# Altium schematic sheet us_#2shc.SchDoc(us_#2shc)
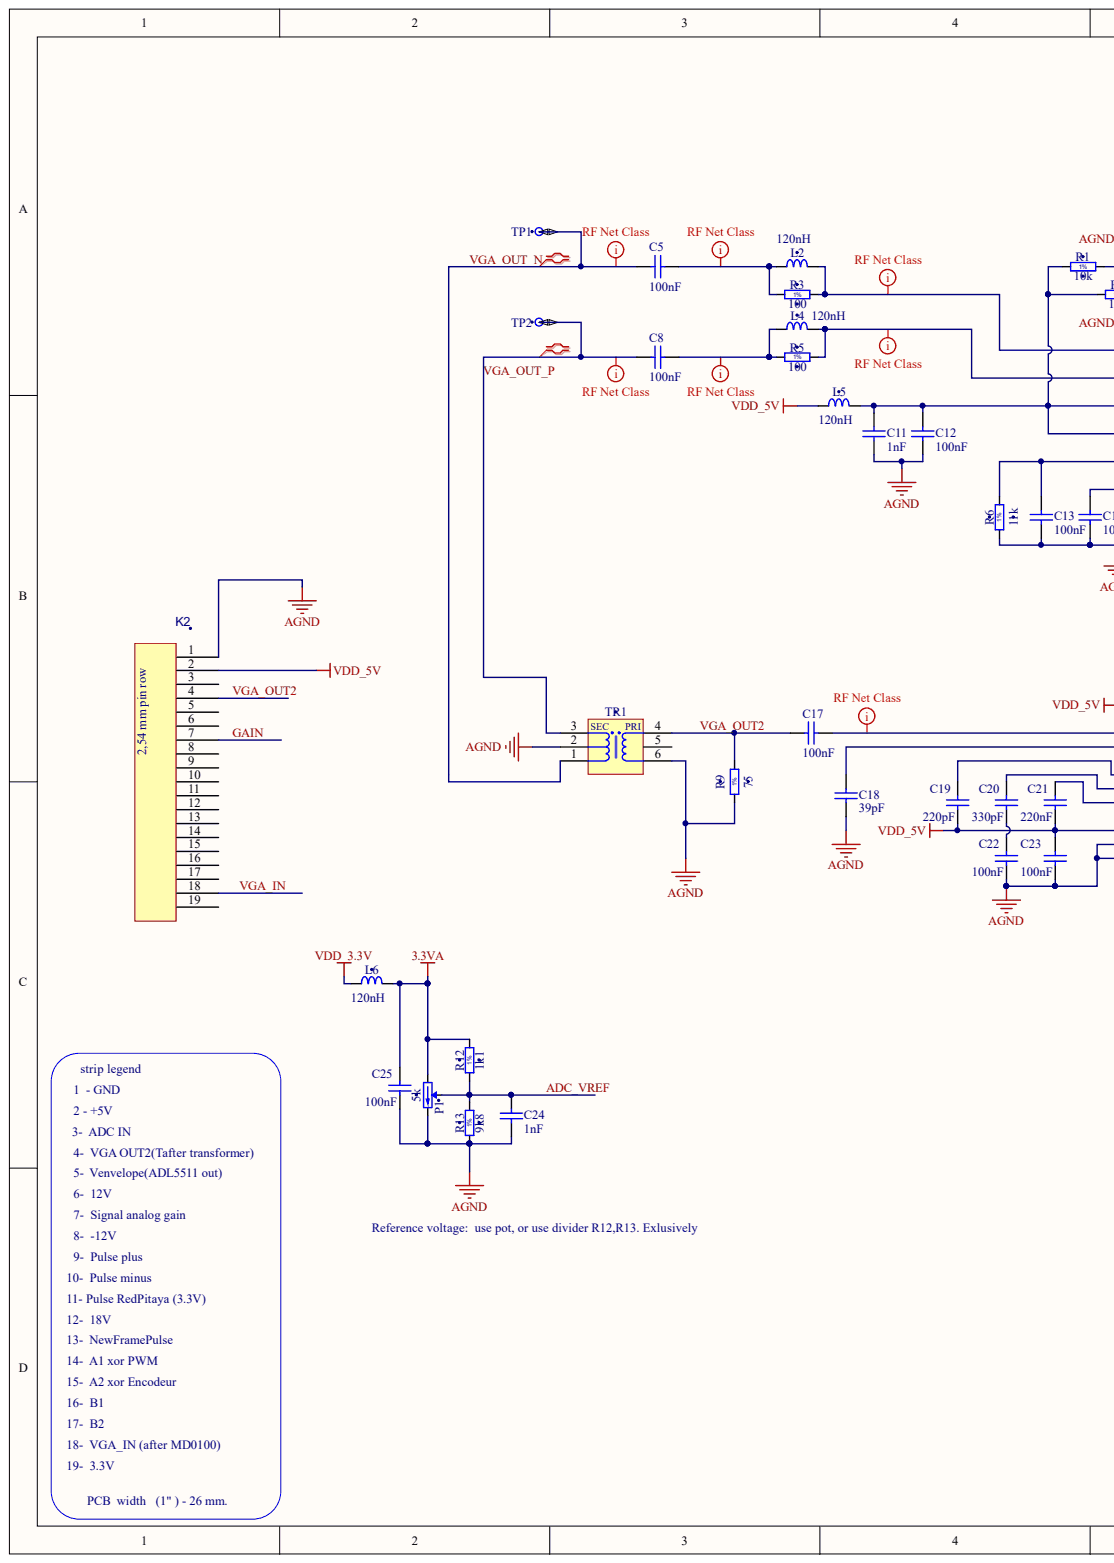
[diagram p0: us_#2shc.SchDoc, left]
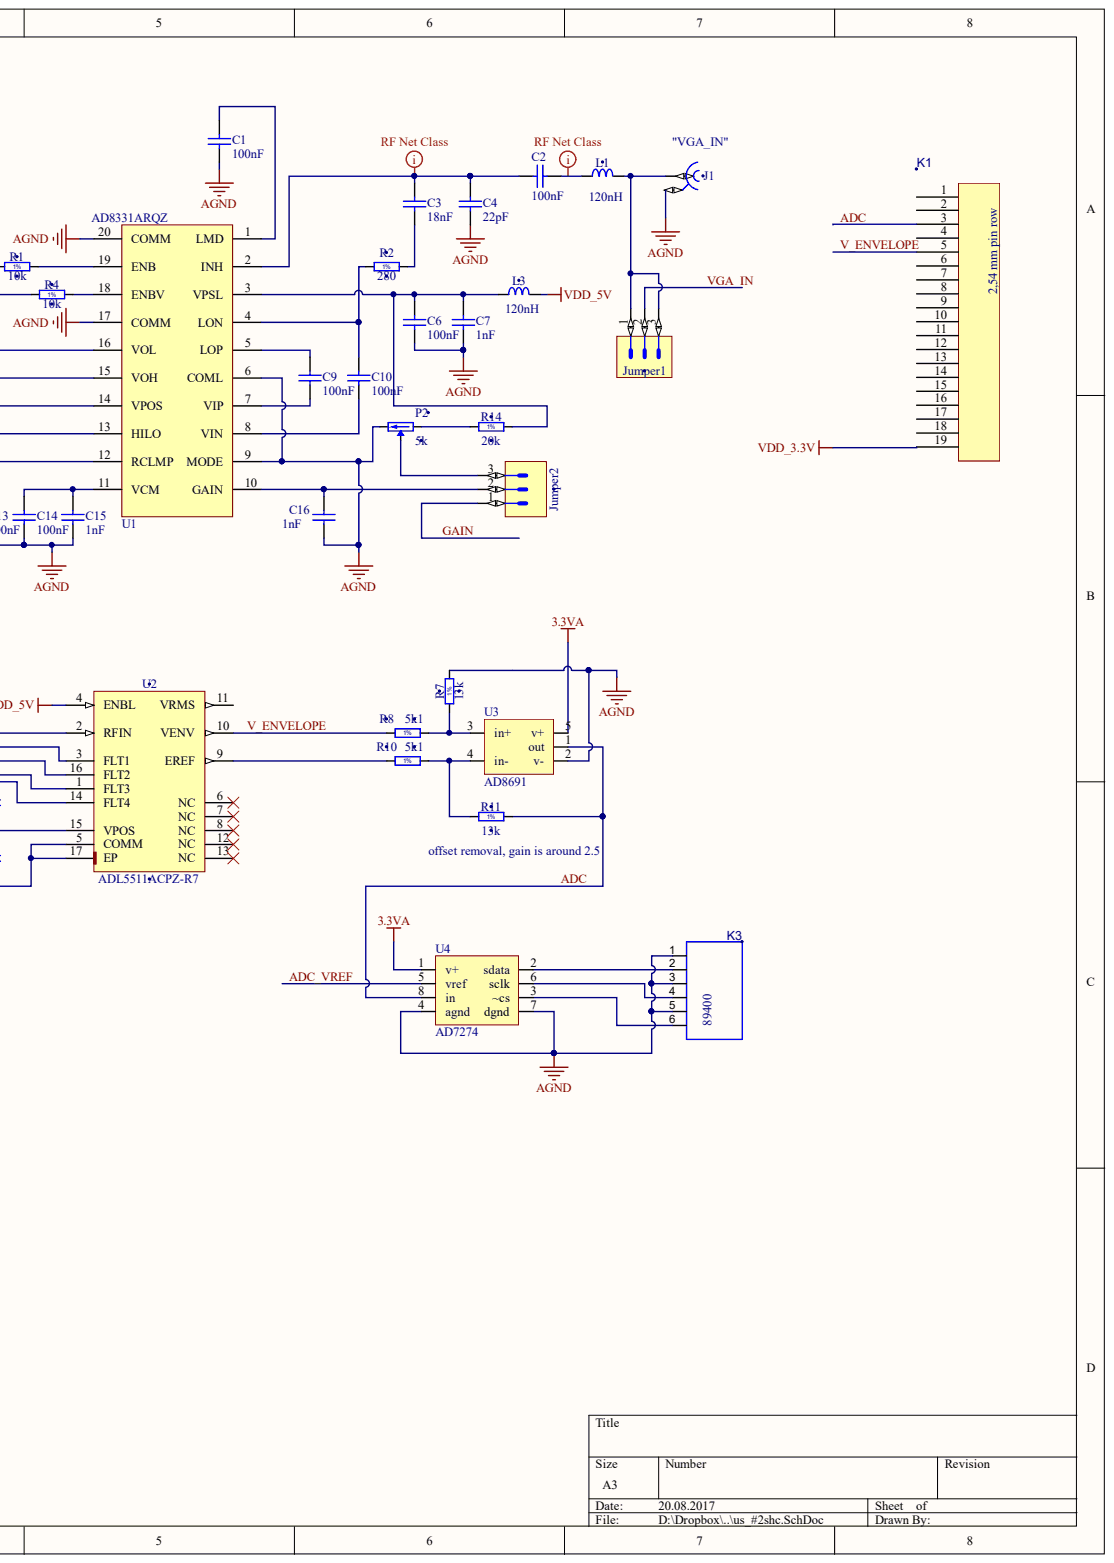
[diagram p1: us_#2shc.SchDoc, right]

## Parts
C1  value 100nF  [SM0603 _3D_cap]  (hidden comment: cap0603)
C2  value 100nF  [SM0603 _3D_cap]  (hidden comment: cap0603)
C3  value 18nF  [SM0603 _3D_cap]  (hidden comment: cap0603)
C4  value 22pF  [SM0603 _3D_cap]  (hidden comment: cap0603)
C5  value 100nF  [SM0603 _3D_cap]  (hidden comment: cap0603)
C6  value 100nF  [SM0603 _3D_cap]  (hidden comment: cap0603)
C7  value 1nF  [SM0603 _3D_cap]  (hidden comment: cap0603)
C8  value 100nF  [SM0603 _3D_cap]  (hidden comment: cap0603)
C9  value 100nF  [SM0603 _3D_cap]  (hidden comment: cap0603)
C10  value 100nF  [SM0603 _3D_cap]  (hidden comment: cap0603)
C11  value 1nF  [SM0603 _3D_cap]  (hidden comment: cap0603)
C12  value 100nF  [SM0603 _3D_cap]  (hidden comment: cap0603)
C13  value 100nF  [SM0603 _3D_cap]  (hidden comment: cap0603)
C14  value 100nF  [SM0603 _3D_cap]  (hidden comment: cap0603)
C15  value 1nF  [SM0603 _3D_cap]  (hidden comment: cap0603)
C16  value 1nF  [SM0603 _3D_cap]  (hidden comment: cap0603)
C17  value 100nF  [SM0603 _3D_cap]  (hidden comment: cap0603)
C18  value 39pF  [SM0603 _3D_cap]  (hidden comment: cap0603)
C19  value 220pF  [SM0603 _3D_cap]  (hidden comment: cap0603)
C20  value 330pF  [SM0603 _3D_cap]  (hidden comment: cap0603)
C21  value 220nF  [SM0603 _3D_cap]  (hidden comment: cap0603)
C22  value 100nF  [SM0603 _3D_cap]  (hidden comment: cap0603)
C23  value 100nF  [SM0603 _3D_cap]  (hidden comment: cap0603)
C24  value 1nF  [SM0603 _3D_cap]  (hidden comment: cap0603)
C25  value 100nF  [SM0603 _3D_cap]  (hidden comment: cap0603)
J1  SMA  [AMPHENOL_132357-11]  (value not drawn)
Jumper1  Family  [SULLINS_GRPB031VWVN]  (value not drawn)
Jumper2  Family  [SULLINS_GRPB031VWVN]  (value not drawn)
K1  PLS_2.54mm-19  [PLS_2.54mm-19]  (value not drawn)
K2  PLS_2.54mm-19  [PLS_2.54mm-19]  (value not drawn)
K3  Molex_89400_061  [Molex_89400-061]  (value not drawn)
L1  75R@100MHz  [INDC2012X105N]  (value not drawn)
L2  75R@100MHz  [INDC2012X105N]  (value not drawn)
L3  75R@100MHz  [INDC2012X105N]  (value not drawn)
L4  75R@100MHz  [INDC2012X105N]  (value not drawn)
L5  75R@100MHz  [INDC2012X105N]  (value not drawn)
L6  75R@100MHz  [INDC2012X105N]  (value not drawn)
P1  5k  [TRIM_BOURNS_3223W]
P2  5k  [TRIM_BOURNS_3223W]
R1  10k  [RESC3216X65N]
R2  280  [RESC3216X65N]
R3  100  [RESC3216X65N]
R4  10k  [RESC3216X65N]
R5  100  [RESC3216X65N]
R6  11k  [RESC3216X65N]
R7  13k  [RESC3216X65N]
R8  5k1  [RESC3216X65N]
R9  75  [RESC3216X65N]
R10  5k1  [RESC3216X65N]
R11  13k  [RESC3216X65N]
R12  1k1  [RESC3216X65N]
R13  9k8  [RESC3216X65N]
R14  20k  [RESC3216X65N]
TP1  Test Point  [NICOMATIC_C12000B]  (value not drawn)
TP2  Test Point  [NICOMATIC_C12000B]  (value not drawn)
TR1  1:1  [XFMR_MINI-CIRCUITS_KK81]  (value not drawn)
U1  AD8331ARQZ  [SOP64P600X175-20N]
U2  ADL5511ACPZ-R7  [QFN50P300X300X80-17N-S175]
U3  AD8691  [TSOT-5(RT-5)]
U4  AD7274  [RM-8]

## Nets
3.3VA: C25.2 L6.2 P1.1 R12.2 U3.5(v+) U4.1(v+)
AGND: C1.1 C4.1 C6.1 C7.1 C11.1 C12.1 C13.1 C14.1 C15.1 C16.1 C18.1 C22.1 C23.1 C24.1 C25.1 J1.2 K2.1 K3.1 K3.3 K3.5 P1.3 P2.3 R6.1 R7.2 R9.1 R13.1 TR1.2 TR1.6 U1.6(COML) U1.9(MODE) U1.17(COMM) U1.20(COMM) U2.5(COMM) U2.17(EP) U3.2(v-) U4.4(agnd) U4.7(dgnd)
VDD_3.3V: K1.19 L6.1
VDD_5V: C19.1 C21.1 C23.2 K2.2 L3.2 L5.1 U2.4(ENBL) U2.15(VPOS)
ADC: K1.3 R11.2 U3.1(out) U4.8(in)
ADC_VREF: C24.2 P1.2 R12.1 R13.2 U4.5(vref)
GAIN: Jumper2.1 K2.7
V_ENVELOPE: K1.5(mm) R8.1 U2.10(VENV)
VGA_IN: Jumper1.2 K2.18
VGA_OUT2: C17.1 K2.4 R9.2 TR1.4
VGA_OUT_N: C5.1 TP1.1 TR1.1
VGA_OUT_P: C8.2 TP2.1 TR1.3
NetC1_2: C1.2 U1.1(LMD)
NetC2_1: C2.1 C3.2 C4.2 U1.2(INH)
NetC2_2: C2.2 L1.1
NetC3_1: C3.1 R2.2
NetC5_2: C5.2 L2.1 R3.1
NetC6_2: C6.2 C7.2 L3.1 R14.2 U1.3(VPSL)
NetC8_1: C8.1 L4.1 R5.1
NetC9_1: C9.1 U1.7(VIP)
NetC9_2: C9.2 U1.5(LOP)
NetC10_1: C10.1 U1.8(VIN)
NetC10_2: C10.2 R2.1 U1.4(LON)
NetC11_2: C11.2 C12.2 L5.2 R1.1 R4.1 U1.13(HILO) U1.14(VPOS)
NetC13_2: C13.2 R6.2 U1.12(RCLMP)
NetC14_2: C14.2 C15.2 U1.11(VCM)
NetC16_2: C16.2 Jumper2.2 U1.10(GAIN)
NetC17_2: C17.2 U2.2(RFIN)
NetC18_2: C18.2 U2.3(FLT1)
NetC19_2: C19.2 U2.16(FLT2)
NetC20_1: C20.1 C22.2
NetC20_2: C20.2 U2.1(FLT3)
NetC21_2: C21.2 U2.14(FLT4)
NetJ1_1: J1.1 Jumper1.1 Jumper1.3 L1.2
NetJumper2_3: Jumper2.3 P2.2
NetK3_2: K3.2 U4.2(sdata)
NetK3_4: K3.4 U4.6(sclk)
NetK3_6: K3.6 U4.3(~cs)
NetL2_2: L2.2 R3.2 U1.16(VOL)
NetL4_2: L4.2 R5.2 U1.15(VOH)
NetP2_1: P2.1 R14.1
NetR1_2: R1.2 U1.19(ENB)
NetR4_2: R4.2 U1.18(ENBV)
NetR7_1: R7.1 R8.2 U3.3(in+)
NetR10_1: R10.1 U2.9(EREF)
NetR10_2: R10.2 R11.1 U3.4(in-)

Not connected: K1 1 2 4 6 7 8 9 10 11 12 13 14 15 16 17 18; K2 3 5(mm) 6 8 9 10 11 12 13 14 15 16 17 19; TR1 5; U2 6(NC) 7(NC) 8(NC) 11(VRMS) 12(NC) 13(NC)

## Library data of the parts
C1-C25 = cap0603: Capacitor  0603 inch; Component Kind: Standard; LatestRevisionDate: 17-Jul-2002; LatestRevisionNote: Re-released for DXP Platform.; PackageDescription: Radial Cap, Thru-Hole; 2 Leads; 0.3 in Pin Spacing; PackageReference: RAD-0.3; Published: 8-Jun-2000; Signal Integrity: Cap; Library Name: alt_vovan.IntLib; Publisher: Altium Limited
J1 = Coax_1Female_1Shield: Manufacturer: AMPHENOL; Manufacturer Part Number: 132357-11; Part Description: SMA End Launch Jack for 1.58mm PCB, 50 Ohm, Flat Tab Contact; ComponentHeight: 7.92mm; Component Kind: Standard; Component Type: Standard; CreateDate: 5/14/2012; Family: SMA; HelpURL: \\cern.ch\dfs\Applications\Altium\Datasheets\AMPHENOL_132357-11.pdf; LatestRevisionDate: 5/14/2012; Mounted: Yes; Part Number: AMPHENOL_132357-11; Pin Count: 2; SMD: Yes; Socket: No; Status: None; Table: AMPHENOL; Author: CERN DEM JLC; Library Name: echOpen_ADC_Card.IntLib
Jumper1 Jumper2 = SULLINS_GRPB031VWVN: Connector 3 Male; Part Description: 3 Contact 0.5" Pitch Single-In-Line Vertical Header; Database Table Name: Miscellaneous; ComponentHeight: 4mm; Component Kind: Standard; Component Type: Standard; CreateDate: 12/29/2015; LatestRevisionDate: 12/29/2015; Manufacturer: SULLINS; Manufacturer Part Number: GRPB031VWVN; Mounted: Yes; Part Number: SULLINS_GRPB031VWVN; Pin Count: 3; SMD: No; Socket: No; Status: None; Table: Miscellaneous; Author: S. Jafar; Library Name: Sof-Connectors.DbLib
K3 = Molex_89400_061: 6 pin vertical 2mm; Component Kind: Standard; Library Name: alt_vovan.IntLib
L1-L6 = Ind_Chip: chip inductance; Manufacturer: MURATA; Manufacturer Part Number: BLM21BB750SN1D; Part Description: SMD EMIFIL Suppression Chip Ferrite Bead; Part Number: IND0805_MURATA_BLM21BB750SN1D; Power: 700mA; Resistance: 0.16R; Table: Inductors SMD; Tolerance: ±25%; Case: 0805; ComponentHeight: 1.05mm; Component Kind: Standard; Component Type: Standard; CreateDate: 12/21/2015; LatestRevisionDate: 12/21/2015; Mounted: Yes; Pin Count: 2; SMD: Yes; Socket: No; Status: None; Value: 75R@100MHz; Author: S. Jafar; Library Name: alt_vovan.IntLib
P1 P2 = Trimming Potentiometer: Manufacturer: BOURNS; Manufacturer Part Number: 3223W-1-502E; Part Description: 11 Turns SMD Trimpot® Trimming Potentiometer (Top Adjust); Part Number: TRIM_11T_5K_BOURNS_3223W-1-502E; Power: 0.125W; Table: Potentiometers SMD; TC: ±100ppm/°C; Tolerance: ±20%; Case: 3223W; ComponentHeight: 4.16mm; Component Kind: Standard; Component Type: Standard; CreateDate: 3/31/2011; Description: Trimming Potentiometer; HelpURL: \\cern.ch\dfs\Applications\Altium\Datasheets\TRIM_BOURNS_3223W.pdf; LatestRevisionDate: 12/18/2012; Mounted: Yes; Pin Count: 3; SMD: Yes; Socket: No; Value: 5k; Author: CERN DEM JLC; Library Name: echOpen_ADC_Card.IntLib
R1-R14 = Res_0603_1%_EU: Manufacturer: GENERIC; Manufacturer1 Part Number: NRC12F4701TRF; Manufacturer Part Number: R1206_4K7_1%_0.25W_100PPM; Part Description: General Purpose Thick Film Chip Resistor; Power: 0.25W; Table: Resistors SMD; TC: ±100ppm/°C; Tolerance: ±1%; Value: 4k7; Case: 1206; Component Kind: Standard; Component Type: Standard; CreateDate: 12/3/2007; Description: Res_0603_1%_EU; HelpURL: \\cern.ch\dfs\Applications\Altium\Datasheets\R1206_NIC_NRC.pdf; LatestRevisionDate: 3/13/2008; Manufacturer1 ComponentHeight: 0.65mm; Manufacturer1 Example: NIC COMPONENT; Mounted: Yes; Part Number: R1206_4K7_1%_0.25W_100PPM; Pin Count: 2; SMD: Yes; Socket: No; Status: Preferred; Author: CERN DEM JLC; Library Name: alt_vovan.IntLib
TP1 TP2 = NICOMATIC_C12000B: Part Description: SMD Test Point; Database Table Name: Miscellaneous; ComponentHeight: 1.5mm; Component Kind: Standard; Component Type: Standard; CreateDate: 3/25/2009; Description: Test Point; Family: Test Point; HelpURL: \\cern.ch\dfs\Applications\Altium\Datasheets\NICOMATIC_C12000B.pdf; LatestRevisionDate: 3/25/2009; Manufacturer: NICOMATIC; Manufacturer Part Number: C12000B; Mounted: Yes; Part Number: NICOMATIC_C12000B; Pin Count: 1; SMD: Yes; Socket: No; Status: None; Table: Miscellaneous; Author: CERN DEM JLC; Library Name: Sof-Connectors.DbLib
TR1 = XFMR_MINI-CIRCUITS_T1-6T-KK81: 50 Ohm 0.015 To 300MHz RF Transformers; Part Description: 50 Ohms 0.015 To 300MHz RF Transformers; ComponentHeight: 6.6mm; Component Kind: Standard; Component Type: Standard; CreateDate: 12/22/2015; Database Table Name: Transformers; LatestRevisionDate: 1/10/2016; Manufacturer: MINI-CIRCUITS; Manufacturer Part Number: T1-6T-KK81; Mounted: Yes; Part Number: XFMR_MINI-CIRCUITS_T1-6T-KK81; Pin Count: 5; SMD: Yes; Socket: No; Status: None; Table: Transformers; Value: 1:1; Author: S. Jafar; Library Name: Sof-Inductors & Transformers.DbLib
U1 = AD8331ARQZ: Manufacturer: Analog Devices; Part Description: Ultralow Noise VGAs with Preamplifier and Programmable RIN; Database Table Name: Analog & Interface; Case: QSOP-20; ComponentHeight: 1.75mm; Component Kind: Standard; Component Type: Standard; CreateDate: 12/20/2015; Device: AD8331ARQZ; LatestRevisionDate: 1/6/2016; Manufacturer Part Number: AD8331ARQZ; Mounted: Yes; PackageDescription: SOP, 20-Leads, Body 8.66x3.91mm, Pitch 0.64mm, IPC Medium Density; Part Number: AD8331ARQZ; Pin Count: 20; SMD: Yes; Socket: No; Table: Analog & Interface; Author: S. Jafar; Library Name: Sof-ICs And Semiconductors.DbLib
U2 = ADL5511ACPZ-R7: DC to 6 GHz Envelope and TruPwr RMS Detector; Manufacturer: ANALOG DEVICES; Database Table Name: Analog & Interface; Case: QFN16; ComponentHeight: 0.8mm; Component Kind: Standard; Component Type: Standard; CreateDate: 11/15/2012; Device: ADL5511ACPZ-R7; HelpURL: \\cern.ch\dfs\Applications\Altium\Datasheets\ADL5511ACPZ-R7.pdf; LatestRevisionDate: 11/15/2012; Manufacturer Part Number: ADL5511ACPZ-R7; Mounted: Yes; PackageDescription: QFN, 0.50mm Pitch, Square; 16 Pin, 3.00mm L X 3.00mm W X 0.80mm H Body (w/Thermal Tab 1.75 X 1.75mm),  IPC Medium Density; Part Description: DC to 6 GHz Envelope and TruPwr RMS Detector; Part Number: ADL5511ACPZ-R7; Pin Count: 17; SMD: Yes; Socket: No; Table: Analog & Interface; Author: CERN DEM JLC; Library Name: Sof-ICs And Semiconductors.DbLib
U3 = OpAmp_TSOT5: Component Kind: Standard; Library Name: alt_vovan.IntLib
U4 = AD7274: adc serial; Component Kind: Standard; Library Name: alt_vovan.IntLib
K1 K2 = PLS_2.54mm-19: Component Kind: Standard; Library Name: alt_vovan.IntLib
